# Altium schematic sheet SDCard.SchDoc(SDCard)
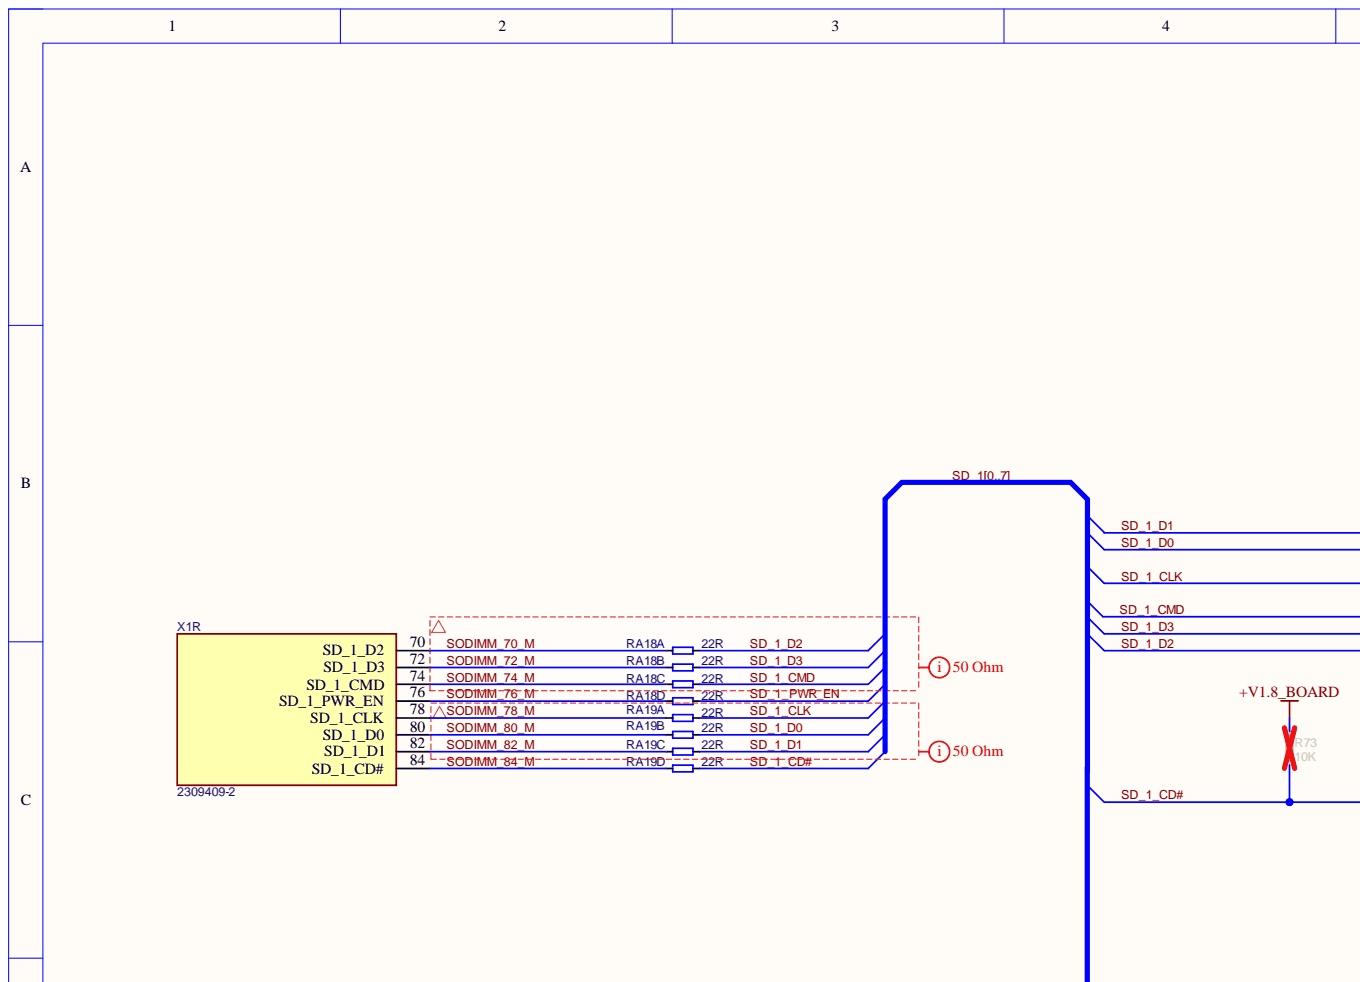
[diagram p0: SDCard.SchDoc, top left]
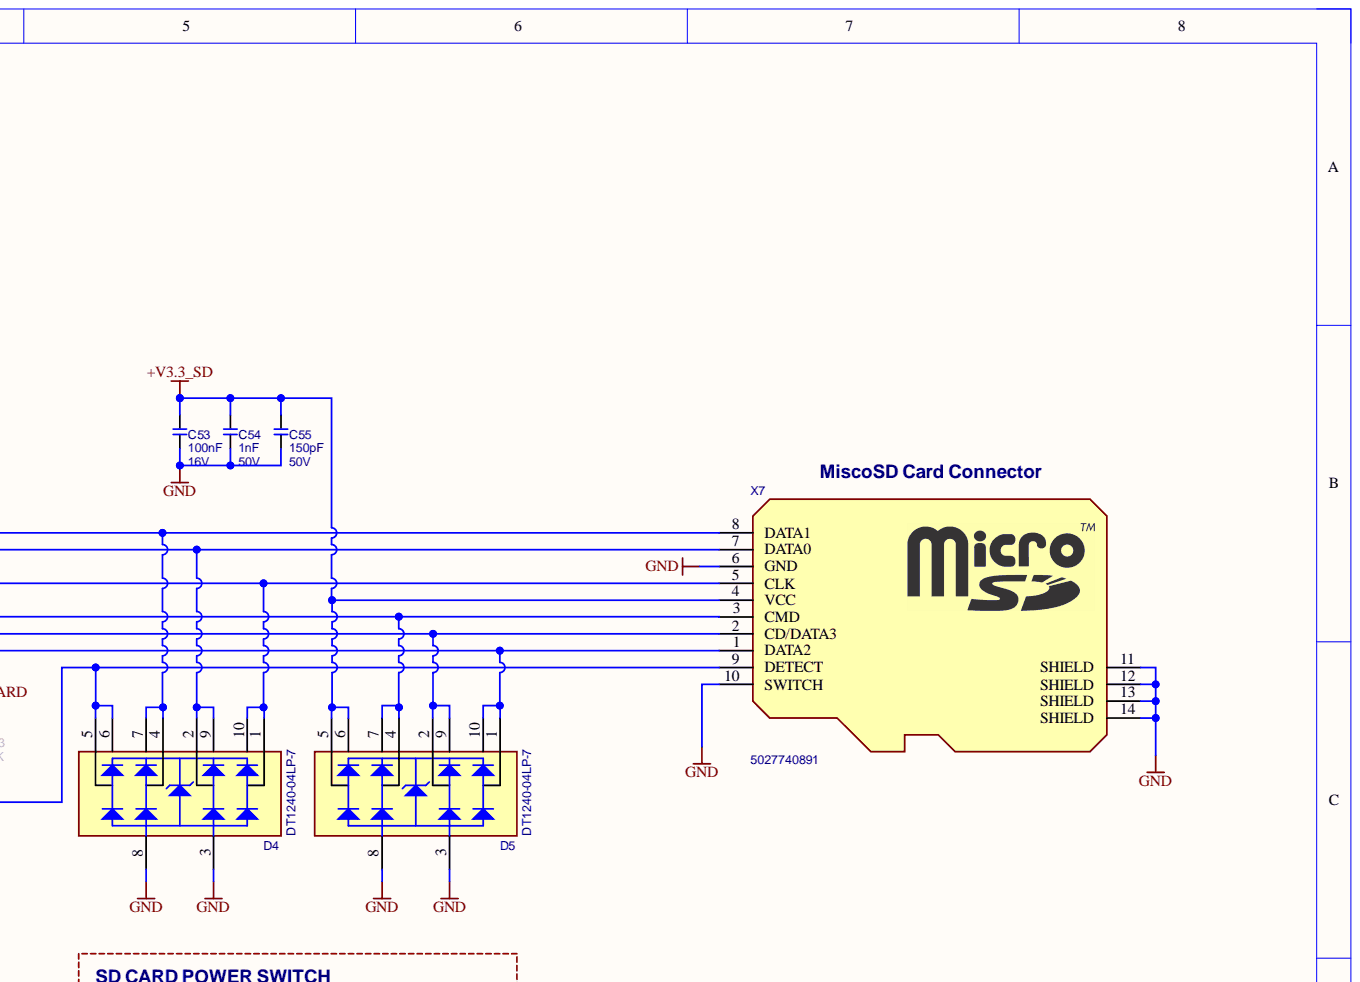
[diagram p1: SDCard.SchDoc, top right]
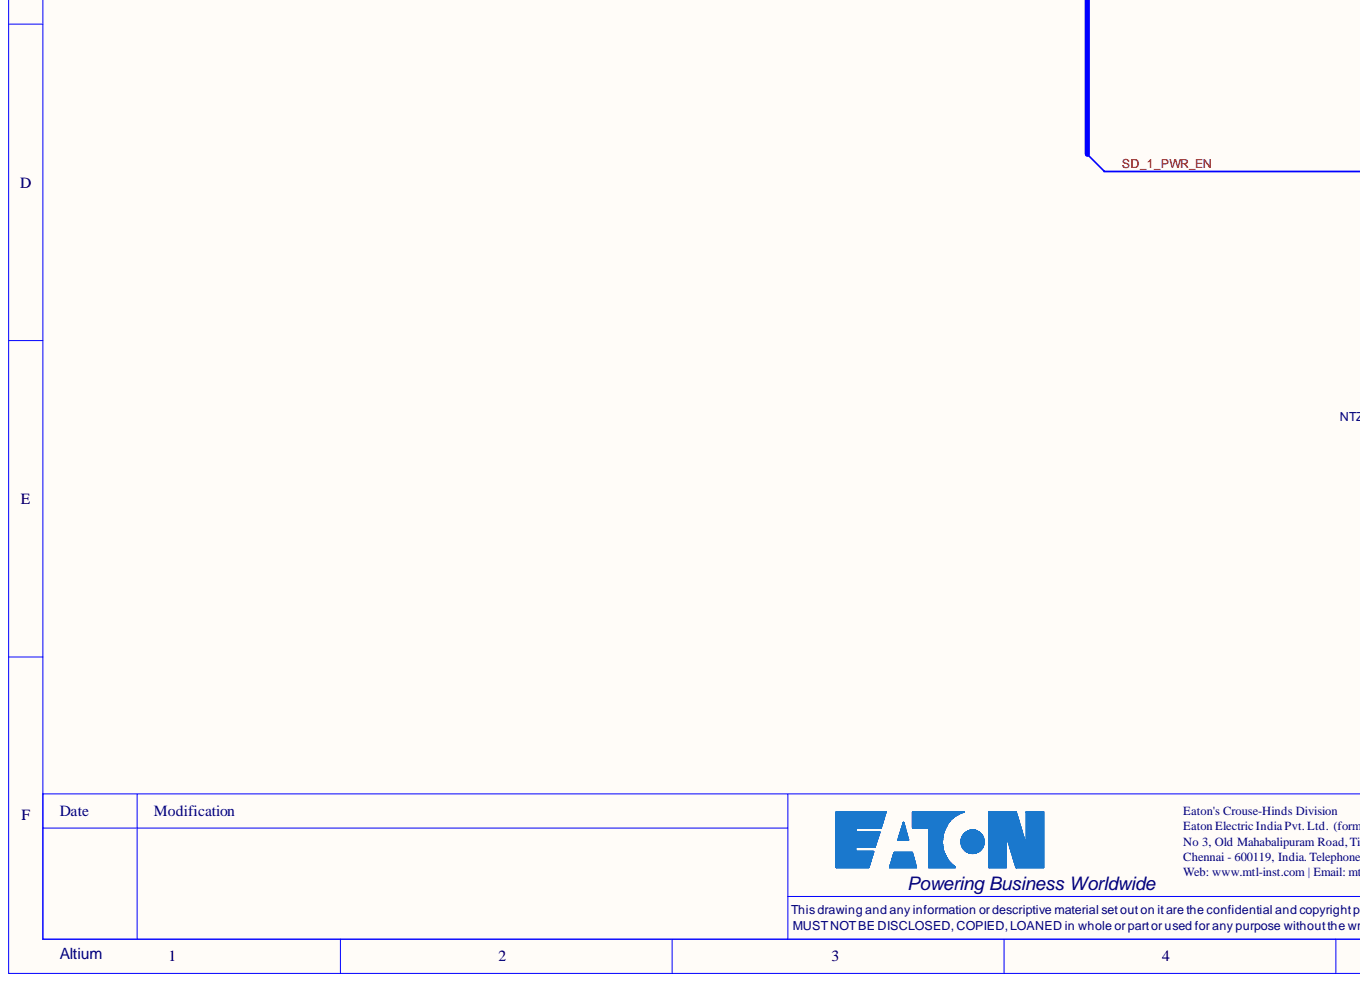
[diagram p2: SDCard.SchDoc, bottom left]
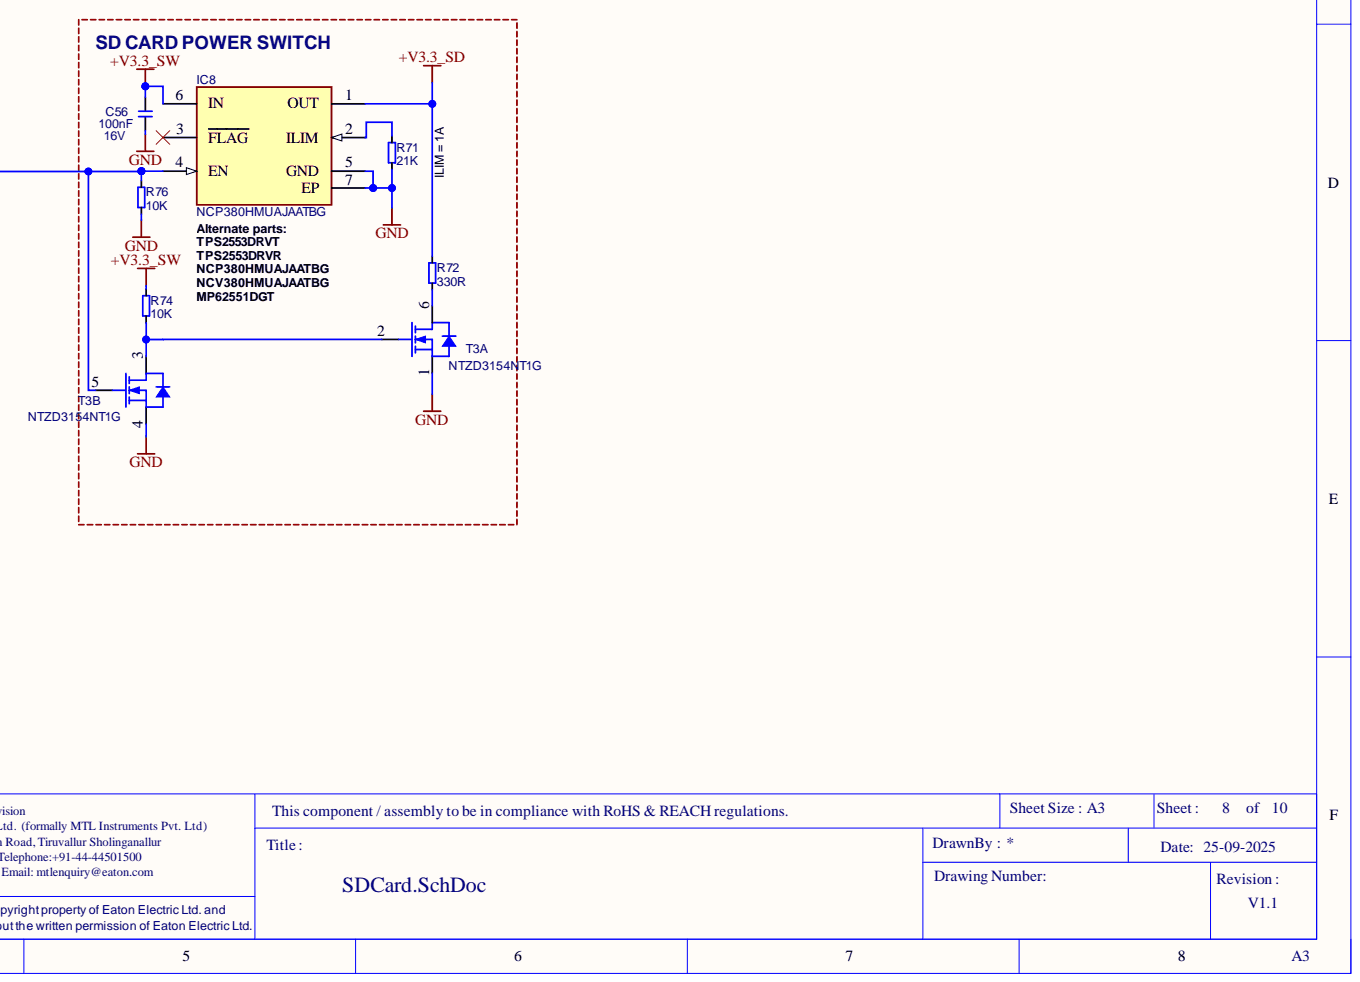
[diagram p3: SDCard.SchDoc, bottom right]

## Parts
C53  100nF  Rating: 16V  [CAPC1005X60N]
C54  1nF  Rating: 50V  [CAPC1005X60N]
C55  150pF  Rating: 50V  [CAPC1005X60N]
C56  100nF  Rating: 16V  [CAPC1005X60N]
D4  DT1240-04LP-7  [D-DI-DT1240-04LP-7]
D5  DT1240-04LP-7  [D-DI-DT1240-04LP-7]
IC8  NCP380HMUAJAATBG  [IC-TI-WSON-6]
R71  21K  [RESC1005X40N]
R72  330R  [RESC1005X40N]
R73  10K  [RESC1005X40N]
R74  10K  [RESC1005X40N]
R76  10K  [RESC1005X40N]
RA18  22R  [T_Resistor_Array_0402_X4]  (parts A,B,C,D)
RA19  22R  [T_Resistor_Array_0402_X4]  (parts A,B,C,D)
T3  Manufacturer Part No.: NTZD3154NT1G  [SOTFL50P160X60-6N]  (hidden comment: T-ONSemi-NTZD3154NT1G)  (parts A,B)
X1  2309409-2  [CON-TE-2309409-2]  (part R)
X7  Manufacturer Part No.: 5027740891  [CON-MOLEX-5027740891]  (hidden comment: MicroSD Holder)

## Nets
+V1.8_BOARD: R73.2
+V3.3_SD: C53.2 C54.2 C55.2 D5.5 D5.6 IC8.1(OUT) R72.2 X7.4(VCC)
+V3.3_SW: C56.2 IC8.6(IN) R74.2
GND: C53.1 C54.1 C55.1 C56.1 D4.3 D4.8 D5.3 D5.8 IC8.5(GND) IC8.7(EP) R71.1 R76.1 T3.1 T3.4 X7.6(GND) X7.10(SWITCH) X7.11(SHIELD) X7.12(SHIELD) X7.13(SHIELD) X7.14(SHIELD)
SD_1_CD#: D4.5 D4.6 R73.1 RA19.8
SD_1_CLK: D4.1 D4.10 RA19.5 X7.5(CLK)
SD_1_CMD: D5.4 D5.7 RA18.7 X7.3(CMD)
SD_1_D0: D4.2 D4.9 RA19.6 X7.7(DATA0)
SD_1_D1: RA19.7
SD_1_D2: D5.1 D5.10 RA18.5 X7.1(DATA2)
SD_1_D3: D5.2 D5.9 RA18.6 X7.2(CD/DATA3)
SD_1_PWR_EN: IC8.4(EN) R76.2 RA18.8 T3.5
SODIMM_70_M: RA18.1 X1.70(SD_1_D2)
SODIMM_72_M: RA18.2 X1.72(SD_1_D3)
SODIMM_74_M: RA18.3 X1.74(SD_1_CMD)
SODIMM_76_M: RA18.4 X1.76(SD_1_PWR_EN)
SODIMM_78_M: RA19.1 X1.78(SD_1_CLK)
SODIMM_80_M: RA19.2 X1.80(SD_1_D0)
SODIMM_82_M: RA19.3 X1.82(SD_1_D1)
SODIMM_84_M: RA19.4 X1.84(SD_1_CD#)
NetD4_4: D4.4 D4.7 X7.8(DATA1)
NetIC8_2: IC8.2(ILIM) R71.2
NetR72_1: R72.1 T3.6
NetR74_1: R74.1 T3.2 T3.3
SD_10:  [also labelled SD_1]
SD_11:  [also labelled SD_1]
SD_12:  [also labelled SD_1]
SD_13:  [also labelled SD_1]
SD_14:  [also labelled SD_1]
SD_15:  [also labelled SD_1]
SD_16:  [also labelled SD_1]
SD_17:  [also labelled SD_1]

Not connected: IC8 3(FLAG); X7 9(DETECT)

## Library data of the parts
C53 C56 = C-X7R-100nF-16V-10%-0402: Capacitor Ceramic X7R 100nF 16V 10% 0402; Manufacturer Name: KEMET; Manufacturer Part No.: C0402C104K4RACTU; Component Kind: Standard; Library Name: SoM_Camera_Encoder.SCHLIB; Tolerance: 10%; Type: X7R; Value: 100nF
C54 = C-X7R-1nF-50V-10%-0402: Capacitor Ceramic X7R 1nF 50V 10% 0402; Manufacturer Name: KEMET; Manufacturer Part No.: C0402C102K5RECTU; Component Kind: Standard; Library Name: SoM_Camera_Encoder.SCHLIB; Tolerance: 10%; Type: X7R; Value: 1nF
C55 = C-X7R-150pF-50V-10%-0402: Capacitor Ceramic X7R 150pF 50V 10% 0402; Manufacturer Name: YAGEO; Manufacturer Part No.: CC0402KRX7R9BB151; Component Kind: Standard; Library Name: SoM_Camera_Encoder.SCHLIB; Tolerance: 10%; Type: X7R; Value: 150pF
D4 D5 = D-DI-DT1240-04LP-7: 4 CHANNEL LOW CAPACITANCE TVS DIODE ARRAY; Manufacturer Name: Diodes; Component Kind: Standard; Manufacturer Part No.: DT1240-04LP-7; Library Name: Mallow.SchLib
IC8 = IC-OnSemi-NCP380HMUAJAATBG: Adjustable, Active High, Constant-Current, Current-Limited Power-Distribution Switch, 0.5 to 2.1 A Current Limit, -40 to 85 degC, 6-pin UDFN package; Manufacturer Name: OnSemi; Component Kind: Standard; Library Name: SoM_Camera_Encoder.SCHLIB; Manufacturer Part No.: NCP380HMUAJAATBG
R71 = R-21K-63mW-1%-0402: Resistor 21 Kohm 63mW 1% 0402; Manufacturer Name: Stackpole Electronics Inc; Manufacturer Part No.: RMCF0402FT21K0; Component Kind: Standard; Library Name: SoM_Camera_Encoder.SCHLIB; Rating: 63mW; Tolerance: 1%; Value: 21K
R72 = R-330R-63mW-5%-0402: Resistor 330 ohm 63mW 5% 0402; Manufacturer Name: Stackpole Electronics Inc; Manufacturer Part No.: RMCF0402JT330R; Component Kind: Standard; Library Name: SoM_Camera_Encoder.SCHLIB; Rating: 63mW; Tolerance: 5%; Value: 330R
R73 R74 R76 = R-10K-63mW-5%-0402: Resistor 10 Kohm 63mW 5% 0402; Manufacturer Name: YAGEO; Manufacturer Part No.: RC0402JR-0710KL; Component Kind: Standard; Library Name: SoM_Camera_Encoder.SCHLIB; Rating: 63mW; Tolerance: 5%; Value: 10K
RA18 RA19 = RA-22R-63mW-5%-0804-div: Resistor Array 22 R 63mW 5% 0804, Concave type; Component Kind: Standard; Library Name: SoM_Camera_Encoder.SCHLIB; Power Dissipation: 63mW; Tolerance: 5%; Value: 22R
T3 = T-ONSemi-NTZD3154NT1G: Dual N-Channel 20V (D-S)  MOSFET; Manufacturer Name: ONSemi; Component Kind: Standard; Library Name: Mallow.SchLib
X1 = CON-TE-2309409-2: DDR4 SODIMM Socket, 260 pins, 5.2mm(H), 0.5mm Pitch; Manufacturer Name: TE Connectivity; Component Kind: Standard; Manufacturer Part No.: 2309409-2; Package: DDR4-SODIMM-260; Temperature Range: -40C to +125C; Library Name: Mallow.SchLib
X7 = CON-MOLEX-5027740891: Micro SD Holder Push Push CD; Manufacturer Name: Molex; No. of ways: 10; Component Kind: Standard; Library Name: Mallow.SchLib
